# Altium schematic sheet OnePhase_MainPower.SchDoc
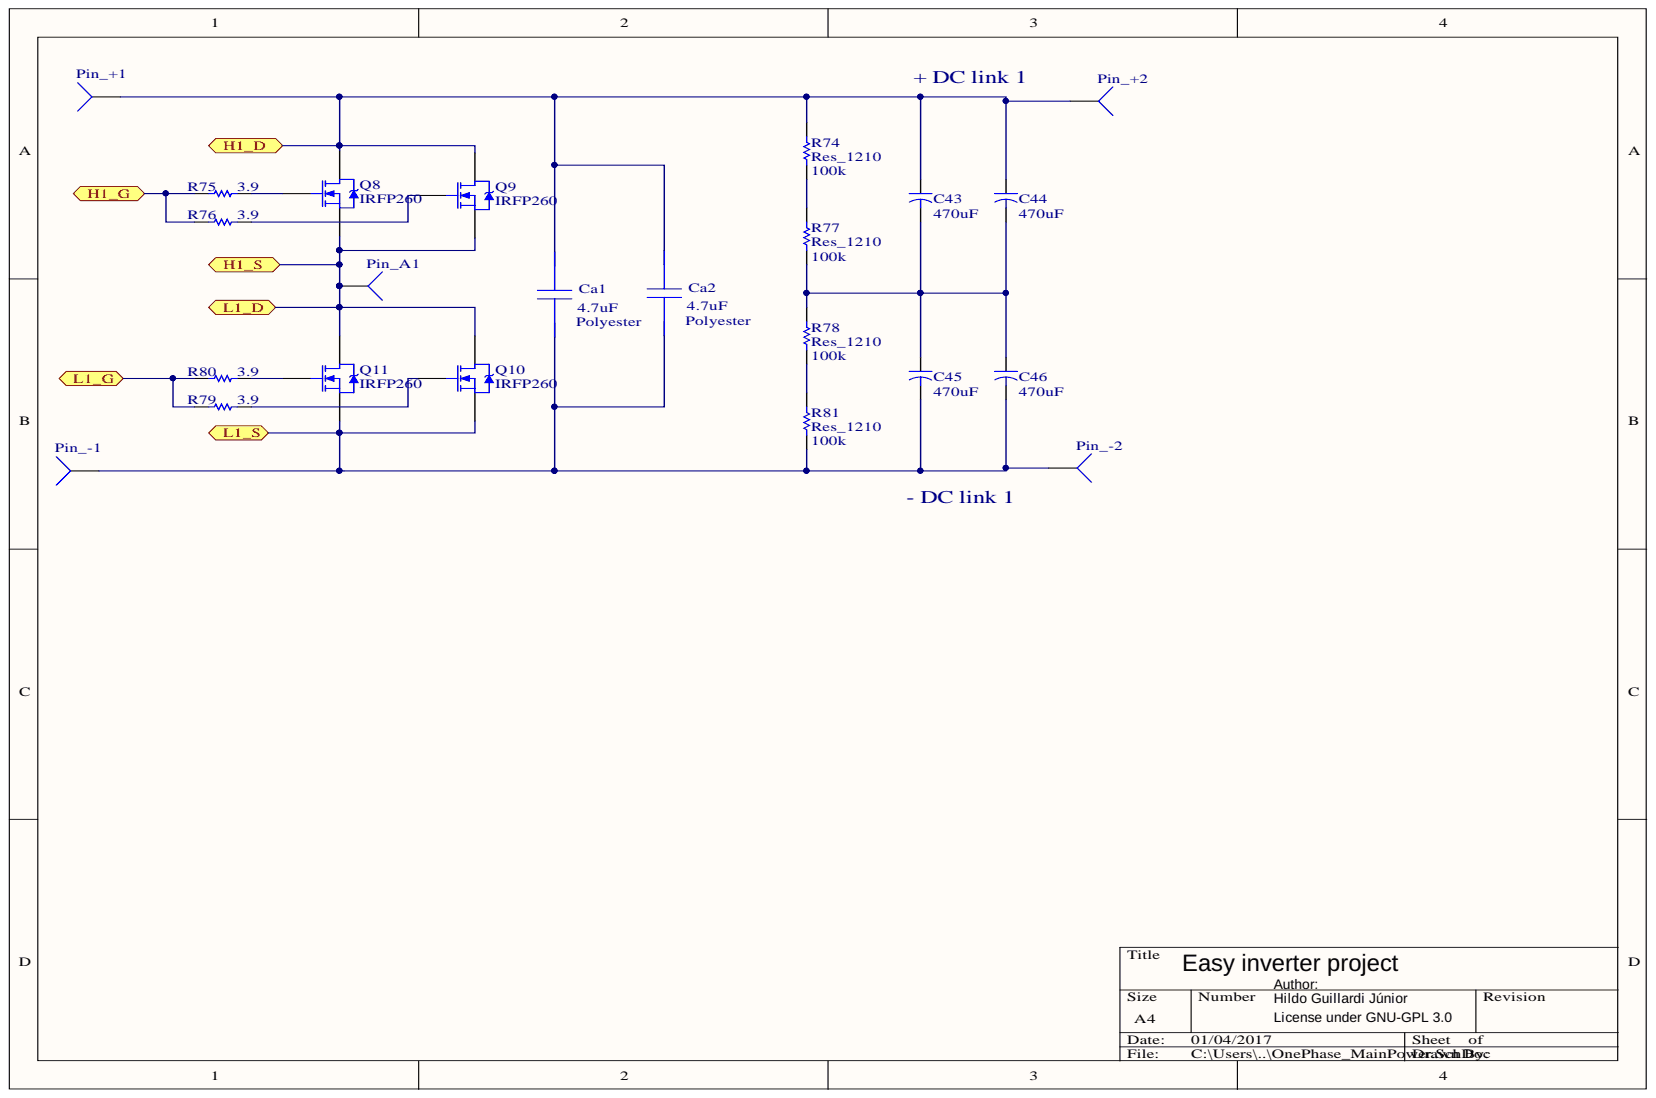
[diagram p0: OnePhase_MainPower.SchDoc]

## Parts
C43  value 470uF  [Capacitor Eletrolitico - duplicate]  (hidden comment: Cap2)
C44  value 470uF  [Capacitor Eletrolitico - duplicate]  (hidden comment: Cap2)
C45  value 470uF  [Capacitor Eletrolitico - duplicate]  (hidden comment: Cap2)
C46  value 470uF  [Capacitor Eletrolitico - duplicate]  (hidden comment: Cap2)
Ca1  Polyester  value 4.7uF  [Capacitor_Pol_2 - 38mm]
Ca2  Polyester  value 4.7uF  [Capacitor_Pol_2]
Pin_+1  Socket  [Power conector]  (value not drawn)
Pin_+2  Socket  [Power conector]  (value not drawn)
Pin_-1  Socket  [Power conector]  (value not drawn)
Pin_-2  Socket  [Power conector]  (value not drawn)
Pin_A1  Socket  [Power conector]  (value not drawn)
Q8  IRFP260  [TO227]
Q9  IRFP260  [TO227]
Q10  IRFP260  [TO227]
Q11  IRFP260  [TO227]
R74  Res_1210  value 100k  [1210_W]
R75  value 3.9  [1210_W]  (hidden comment: Res_1210)
R76  value 3.9  [1210_W]  (hidden comment: Res_1210)
R77  Res_1210  value 100k  [1210_W]
R78  Res_1210  value 100k  [1210_W]
R79  value 3.9  [1210_W]  (hidden comment: Res_1210)
R80  value 3.9  [1210_W]  (hidden comment: Res_1210)
R81  Res_1210  value 100k  [1210_W]

## Ports
H1_D, H1_G, H1_S, L1_D, L1_G, L1_S

## Nets
H1_D: C43.1 C44.1 Ca1.1 Ca2.1 Pin_+1.1 Pin_+2.1 Q8.2 Q9.2 R74.1 ; port H1_D
H1_G: R75.2 R76.2 ; port H1_G
H1_S: Pin_A1.1 Q8.3 Q9.3 Q10.2 Q11.2 ; port H1_S, port L1_D
L1_G: R79.2 R80.2 ; port L1_G
L1_S: C45.2 C46.2 Ca1.2 Ca2.2 Pin_-1.1 Pin_-2.1 Q10.3 Q11.3 R81.2 ; port L1_S
NetC43_2: C43.2 C44.2 C45.1 C46.1 R77.2 R78.1
NetQ8_1: Q8.1 R75.1
NetQ9_1: Q9.1 R76.1
NetQ10_1: Q10.1 R79.1
NetQ11_1: Q11.1 R80.1
NetR74_2: R74.2 R77.1
NetR78_2: R78.2 R81.1

## Library data of the parts
C43-C46 = Cap2: Capacitor; Component Kind: Standard; Library Name: Miscellaneous Devices.IntLib; PackageDescription: Capacitor; 2 Leads; PackageReference: CAPR5-4X5; Published: 23-Sep-2002; Signal Integrity: Cap; Simulation: CAP; Publisher: Altium Limited
Ca1 Ca2 = GRM21BC81E475KA12L: GRM21BC81E475KA1; Manufacturer Name: MuRata; Component Kind: Standard; Library Name: TI WEBENCH; Manufacturer Part Number: GRM21BC81E475KA12L; PackageReference: GRM21BC81E475KA12L
Q8-Q11 = IRFP260: HEXFET Power MOSFET; Note: Pin 2 is electrically connected to the Heatsink (4).; Code_JEDEC: TO-247-AC; Component Kind: Standard; ComponentLink1Description: Manufacturer Link; ComponentLink1URL: http://www.irf.com/; ComponentLink2Description: Datasheet; ComponentLink2URL: http://www.irf.com/product-info/datasheets/data/irfp260.pdf; DatasheetVersion: PD-9.755; LatestRevisionDate: 17-Jul-2002; LatestRevisionNote: Re-released for DXP Platform.; Library Name: IR Discrete MOSFET - Power.IntLib; PackageDescription: SIP with Mounting Hole; 3 Leads; Body 20 x 15.6 x 5 mm (typ); Pitch 5.45 mm; PackageReference: TO-247AC; PackageVersion: Aug-1997; Published: 8-Jun-2000; Simulation: IRFP260; Publisher: Altium Limited
R74-R81 = Res_0805: Resistor; Manufacturer: Generic Components; Class: Passive; Component Kind: Standard; Published: 08/06/2000; Signal Integrity: Res1; Simulation: RESISTOR; SubClass: Resistor; Library Name: Inversor_LCEE.SchLib; Publisher: Altium Hobart Technology Centre
Pin_+1 Pin_+2 Pin_-1 Pin_-2 Pin_A1 = Socket: Component Kind: Standard; Description: Socket; LatestRevisionDate: 17-Jul-2002; LatestRevisionNote: Re-released for DXP Platform.; Library Name: Miscellaneous Connectors.IntLib; Signal Integrity: Connector; Publisher: Altium Limited
